# Altium schematic sheet Sensors_and_Servo.SchDoc(Sensors_and_Servo)
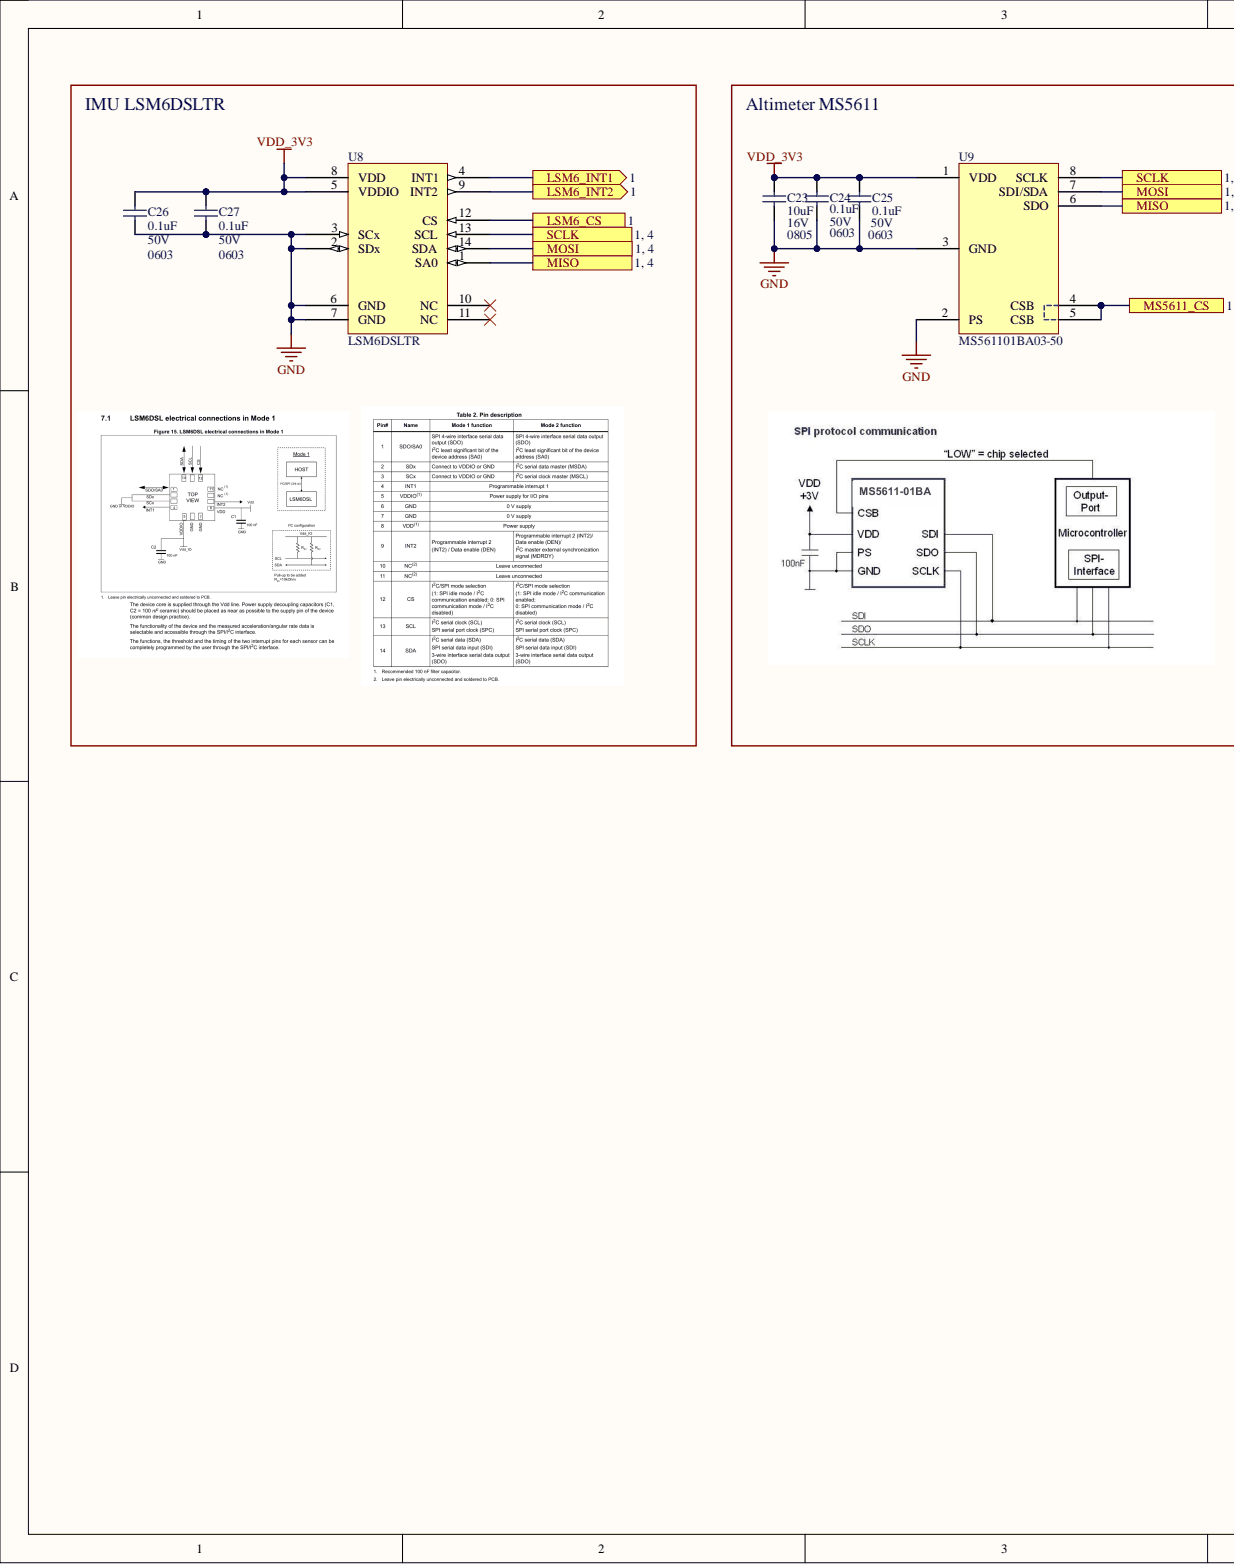
[diagram p0: Sensors_and_Servo.SchDoc, left]
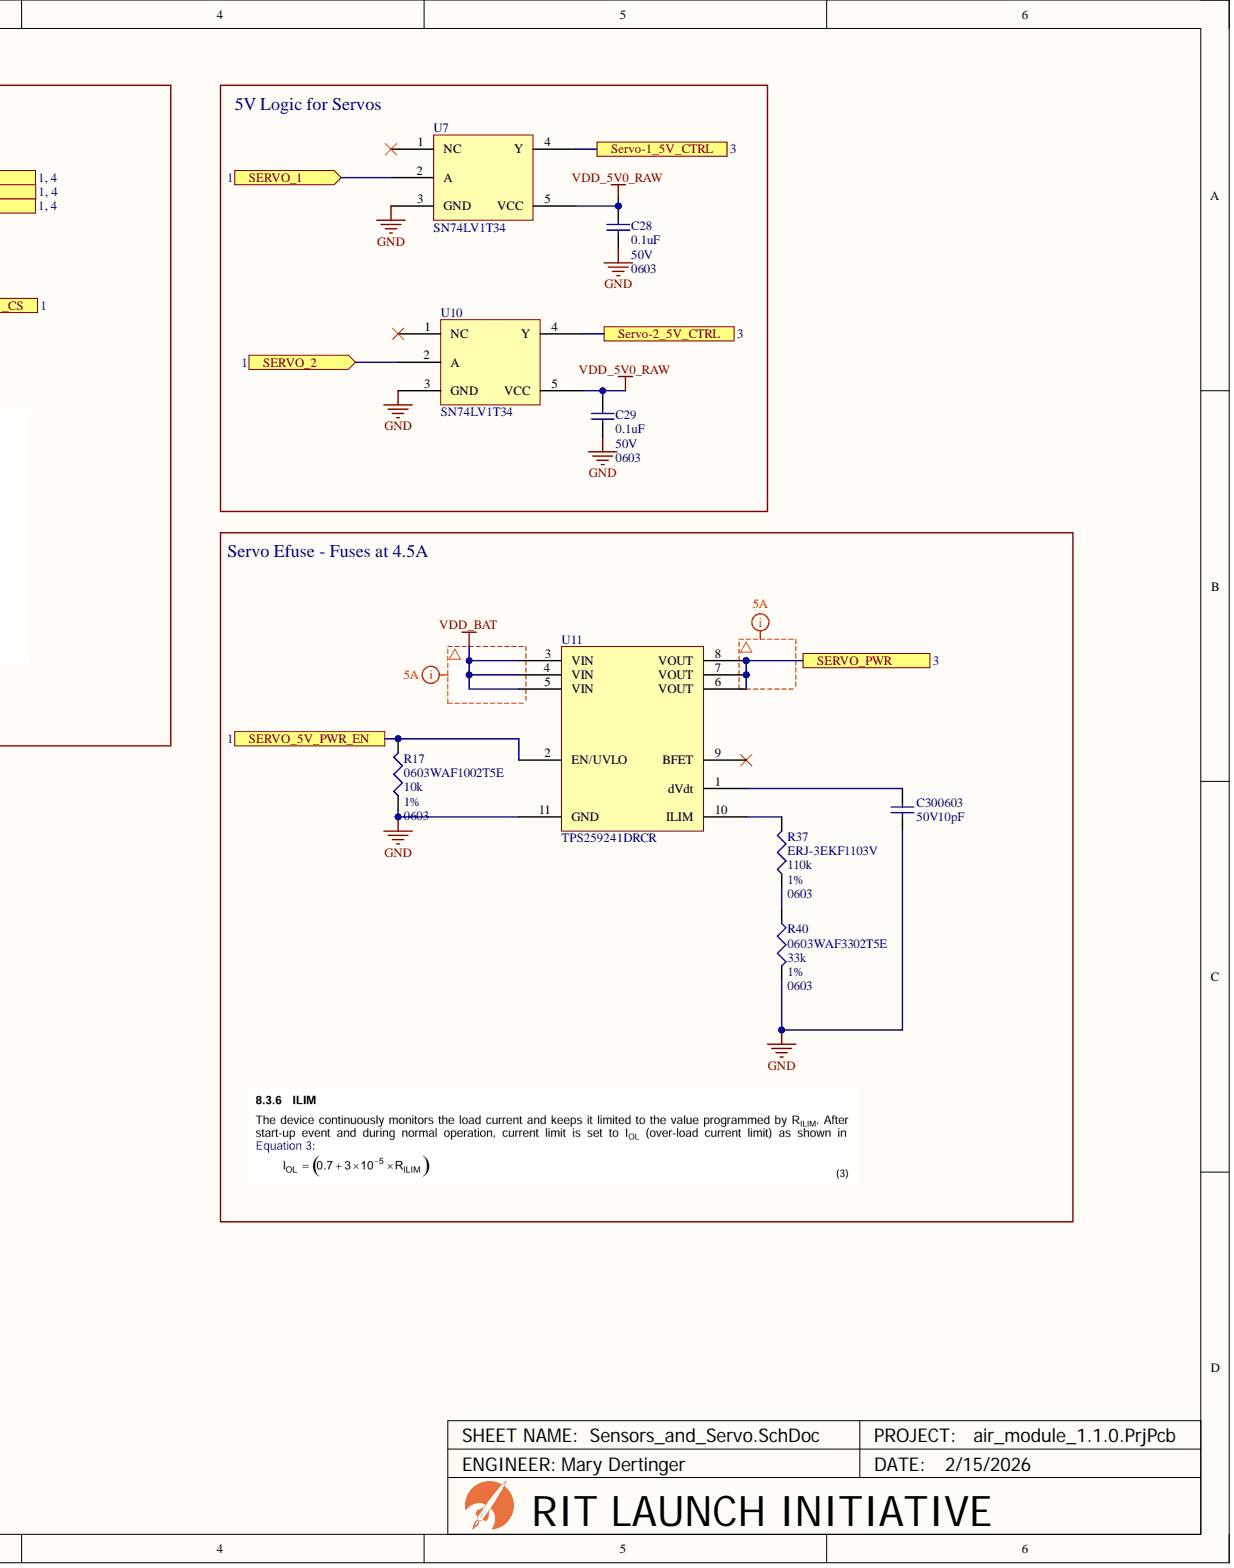
[diagram p1: Sensors_and_Servo.SchDoc, right]

## Parts
C23  Capacitance: 10uF  Case Code (Imperial): 0805  Voltage: 16V  (hidden comment: CL21A106KOQNNNE)
C24  Capacitance: 0.1uF  Case Code (Imperial): 0603  Voltage: 50V  (hidden comment: CC0603KRX7R9BB104)
C25  Capacitance: 0.1uF  Case Code (Imperial): 0603  Voltage: 50V  (hidden comment: CC0603KRX7R9BB104)
C26  Capacitance: 0.1uF  Case Code (Imperial): 0603  Voltage: 50V  (hidden comment: CC0603KRX7R9BB104)
C27  Capacitance: 0.1uF  Case Code (Imperial): 0603  Voltage: 50V  (hidden comment: CC0603KRX7R9BB104)
C28  Capacitance: 0.1uF  Case Code (Imperial): 0603  Voltage: 50V  (hidden comment: CC0603KRX7R9BB104)
C29  Capacitance: 0.1uF  Case Code (Imperial): 0603  Voltage: 50V  (hidden comment: CC0603KRX7R9BB104)
C30  value 10pF  Case Code (Imperial): 0603  Voltage: 50V  (hidden comment: CL10C100JB8NNNC)
R17  0603WAF1002T5E  Case/Package: 0603  Resistance: 10k  Tolerance: 1%
R37  ERJ-3EKF1103V  Case/Package: 0603  Resistance: 110k  Tolerance: 1%
R40  0603WAF3302T5E  Case/Package: 0603  Resistance: 33k  Tolerance: 1%
U7  SN74LV1T34
U8  LSM6DSLTR
U9  MS561101BA03-50
U10  SN74LV1T34
U11  TPS259241DRCR

## Ports
LSM6_CS, LSM6_INT1, LSM6_INT2, MISO, MOSI, MS5611_CS, SCLK, Servo-1_5V_CTRL, Servo-2_5V_CTRL, SERVO_1, SERVO_2, SERVO_5V_PWR_EN, SERVO_PWR

## Nets
GND: C23.1 C24.1 C25.1 C26.1 C27.1 C28.1 C29.1 C30.1 R17.1 R40.2 U7.3(GND) U8.2(SDx) U8.3(SCx) U8.6(GND) U8.7(GND) U9.2(PS) U9.3(GND) U10.3(GND) U11.11(GND)
VDD_3V3: C23.2 C24.2 C25.2 C26.2 C27.2 U8.5(VDDIO) U8.8(VDD) U9.1(VDD)
VDD_5V0_RAW: C28.2 C29.2 U7.5(VCC) U10.5(VCC)
VDD_BAT: U11.3(VIN) U11.4(VIN) U11.5(VIN)
LSM6_CS: U8.12(CS) ; port LSM6_CS
LSM6_INT1: U8.4(INT1) ; port LSM6_INT1
LSM6_INT2: U8.9(INT2) ; port LSM6_INT2
MISO: U8.1(SA0) U9.6(SDO) ; port MISO
MOSI: U8.14(SDA) U9.7(SDI/SDA) ; port MOSI
MS5611_CS: U9.4 U9.5 ; port MS5611_CS
SCLK: U8.13(SCL) U9.8(SCLK) ; port SCLK
Servo-1_5V_CTRL: U7.4(Y) ; port Servo-1_5V_CTRL
Servo-2_5V_CTRL: U10.4(Y) ; port Servo-2_5V_CTRL
SERVO_1: U7.2(A) ; port SERVO_1
SERVO_2: U10.2(A) ; port SERVO_2
SERVO_5V_PWR_EN: R17.2 U11.2(EN/UVLO) ; port SERVO_5V_PWR_EN
SERVO_PWR: U11.6(VOUT) U11.7(VOUT) U11.8(VOUT) ; port SERVO_PWR
NetC30_2: C30.2 U11.1(dVdt)
NetR37_1: R37.1 R40.1
NetR37_2: R37.2 U11.10(ILIM)

Not connected: U7 1(NC); U8 10(NC) 11(NC); U10 1(NC); U11 9(BFET)

## Library data of the parts
C23 = CMP-001-0207-2: CAP CER 10UF 16V X5R 0805; Tolerance: 10%; Case Code (Metric): 2012; Case/Package: 0805; Component Kind: Standard; Construction: Flat; Depth: 1.25mm; Dielectric: X5R; Height: 1.25mm; JLC Part #: C1713; Lead Free: Lead Free; Length: 2mm; Max Operating Temperature: 85°C; Min Operating Temperature: -55°C; Mount: Surface Mount; Package Quantity: 1; Packaging: Tape and Reel; Radiation Hardening: No; RoHS Compliant: Yes; Series: CL; Thickness: 0.055inch; Voltage Rating: 16V; Voltage Rating (DC): 16V; Width: 0.049inch; Library Name: RIT Launch Initiative
C24-C29 = CMP-001-0242-3: CAP CER 0.1UF 50V X7R 0603; Dielectric Material: Ceramic; Tolerance: 10%; Case Code (Metric): 1608; Case/Package: 0603; Component Kind: Standard; Depth: 0.8mm; Dielectric: X7R; Halogen Free: Halogen Free; Height: 0.8mm; JLCPCB Part #: C14663; Lead Free: Lead Free; Length: 0.063inch; Max Operating Temperature: 125°C; Min Operating Temperature: -55°C; Mount: Surface Mount; Number of Pins: 2; Package Quantity: 1; Packaging: Tape and Reel; Radiation Hardening: No; REACH SVHC: No SVHC; RoHS Compliant: Yes; Series: CC; Termination: SMD/SMT; Thickness: 0.035inch; Voltage Rating: 50V; Width: 0.031inch; Library Name: RIT Launch Initiative
C30 = CMP-001-0335-1: Ceramic capacitor SMD-0603 10pF /50V NP0# J +-5%, Samsung, RoHS; Dielectric: C0G; Tolerance: 5%; Case Code (Metric): 1608; Case/Package: 0603; Component Kind: Standard; Construction: Flat; Contact Plating: Tin; Depth: 0.8mm; Height: 0.8mm; Length: 1.6mm; Max Operating Temperature: 125°C; Min Operating Temperature: -55°C; Mounting Technology: Surface Mount; Package Quantity: 1; Packaging: Tape and Reel; Radiation Hardening: No; RoHS Compliant: Yes; Series: CL; Thickness: 0.035inch; Voltage Rating: 50V; Width: 0.031inch; Library Name: RIT Launch Initiative
R17 = CMP-001-0268-1: RES 10K OHM 1% 1/10W 0603; Component Kind: Standard; JLCPCB Part #: C25804; RoHS Compliant: Yes; Library Name: RIT Launch Initiative
R37 = CMP-001-0046-1: RES SMD 110K OHM 1% 1/10W 0603; Component Kind: Standard; Library Name: RIT Launch Initiative
R40 = CMP-001-0347-1: RES 33K OHM 1% 1/10W 0603; Component Kind: Standard; JLCPCB Part #: C4216; Library Name: RIT Launch Initiative
U7 U10 = CMP-001-0449-3: LOGIC BUFFER; Component Kind: Standard; JLCPCB Part #: C100024; Library Name: RIT Launch Initiative
U8 = CMP-001-0281-1: IMU ACCEL/GYRO I2C/SPI 14LGA; Interface: I2C,SPI; Max Supply Voltage: 3.6V; Min Supply Voltage: 1.71V; Operating Supply Current: 650uA; Output Type: SPI,I2C,Digital; Resolution: 16b; Sensor Type: Accelerometer,Gyroscope,6 Axis,Temperature; Case/Package: LGA; Component Kind: Standard; JLCPCB Part #: C126672; Max Operating Temperature: 85°C; Min Operating Temperature: -40°C; Mounting Technology: Surface Mount; Package Quantity: 5000; Packaging: Cut Tape; Pins: 14; RoHS Compliant: Yes; Series: -; Weight: 0.004233oz; Library Name: RIT Launch Initiative
U9 = CMP-001-0204-6: BAROMETRIC PRESSURE SENSOR; Component Kind: Standard; JLCPCB Part #: C15639; Library Name: RIT Launch Initiative
U11 = CMP-001-0530-1: 4.5-V to 13.8-V, 28mΩ, 1-5A eFuse with driver for external blocking FET 10-VSON -40 to 85; Manufacturer Lifecycle Status: ACTIVE; Max Output current: 5A; Max Supply Current: 550µA; Max Supply Voltage: 13.8V; Min Supply Voltage: 4.5V; Number of Channels: 1; Operating Supply Current: 420µA; Operating Supply Voltage: 12V; Component Kind: Standard; Contact Plating: Gold; Features: Auto Retry, OVP, Thermal Limit; Height: 1mm; Lead Free: Lead Free; Length: 3mm; Lifecycle Status: Production; Max Operating Temperature: 85°C; Min Operating Temperature: -40°C; Mounting Technology: Surface Mount; Packaging: Tape & Reel (TR); Pins: 10; RoHS: Compliant; Schedule B: 8542390000, 8542390000; Thickness: 900µm; Width: 3mm; Library Name: RIT Launch Initiative
